# Altium schematic sheet 419-005-01-02_PG 12 - USB Connectorss.SchDoc("419-005-01-02_PG 12 - USB Connectorss")
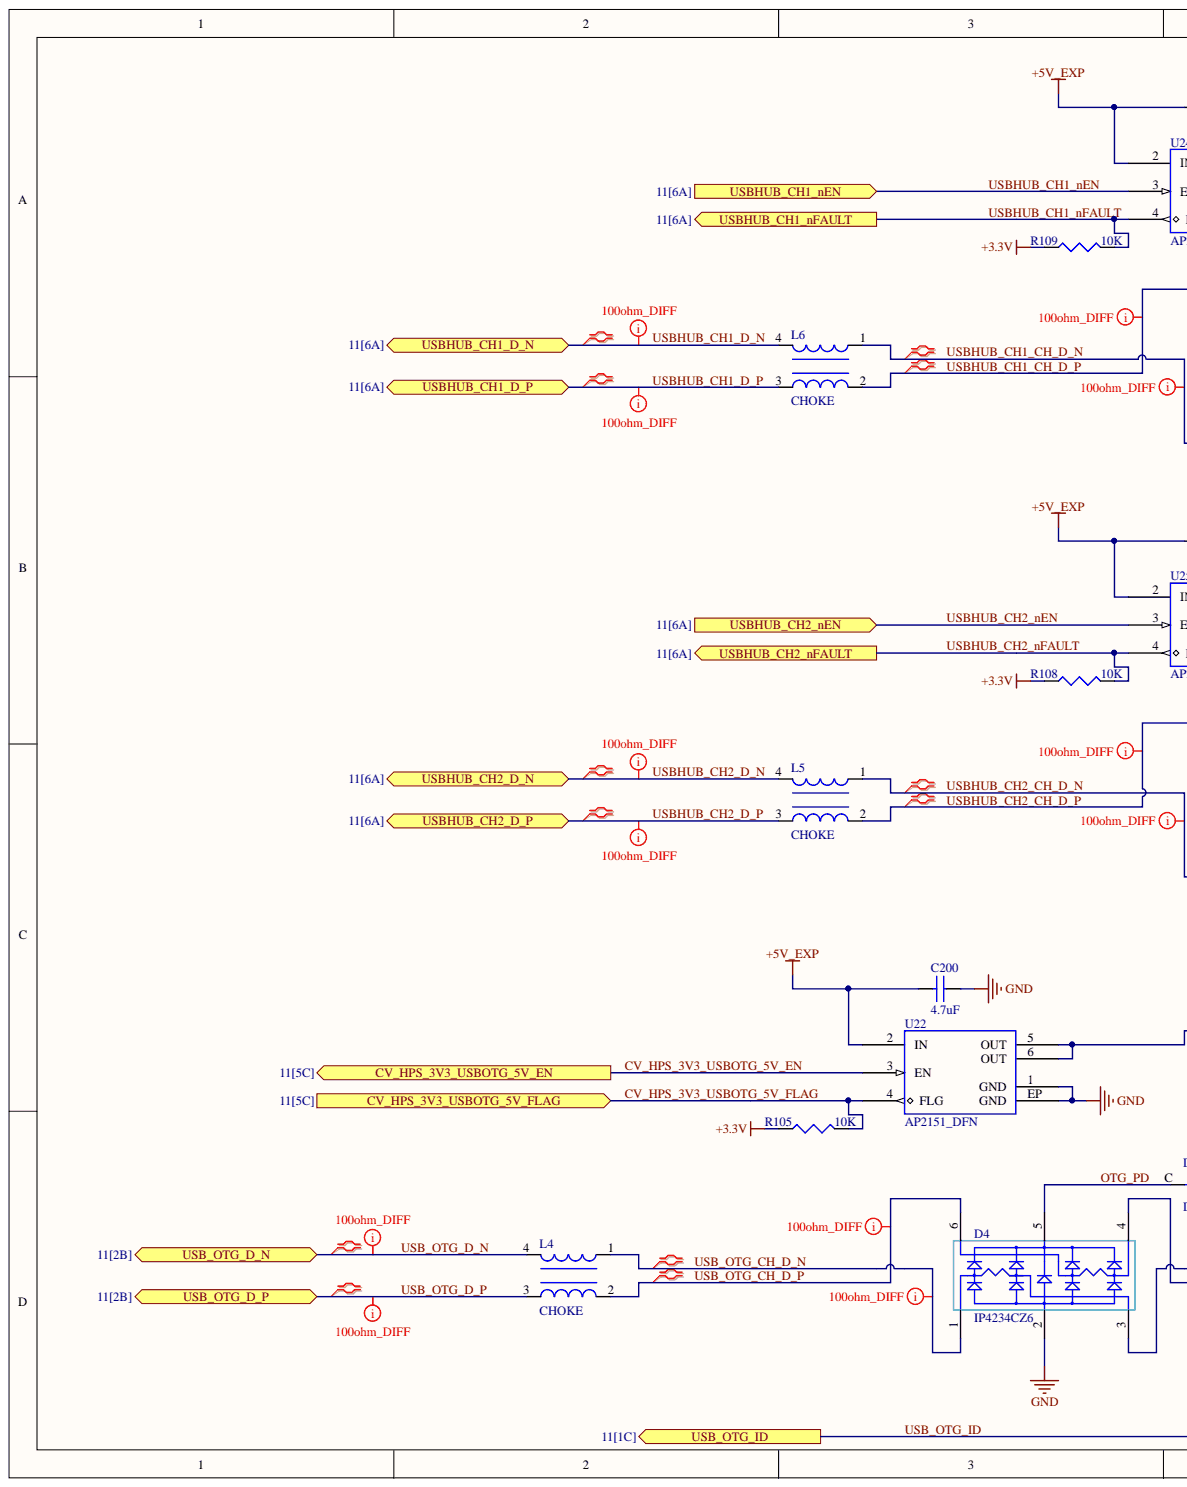
[diagram p0: 419-005-01-02_PG 12 - USB Connectorss.SchDoc, left]
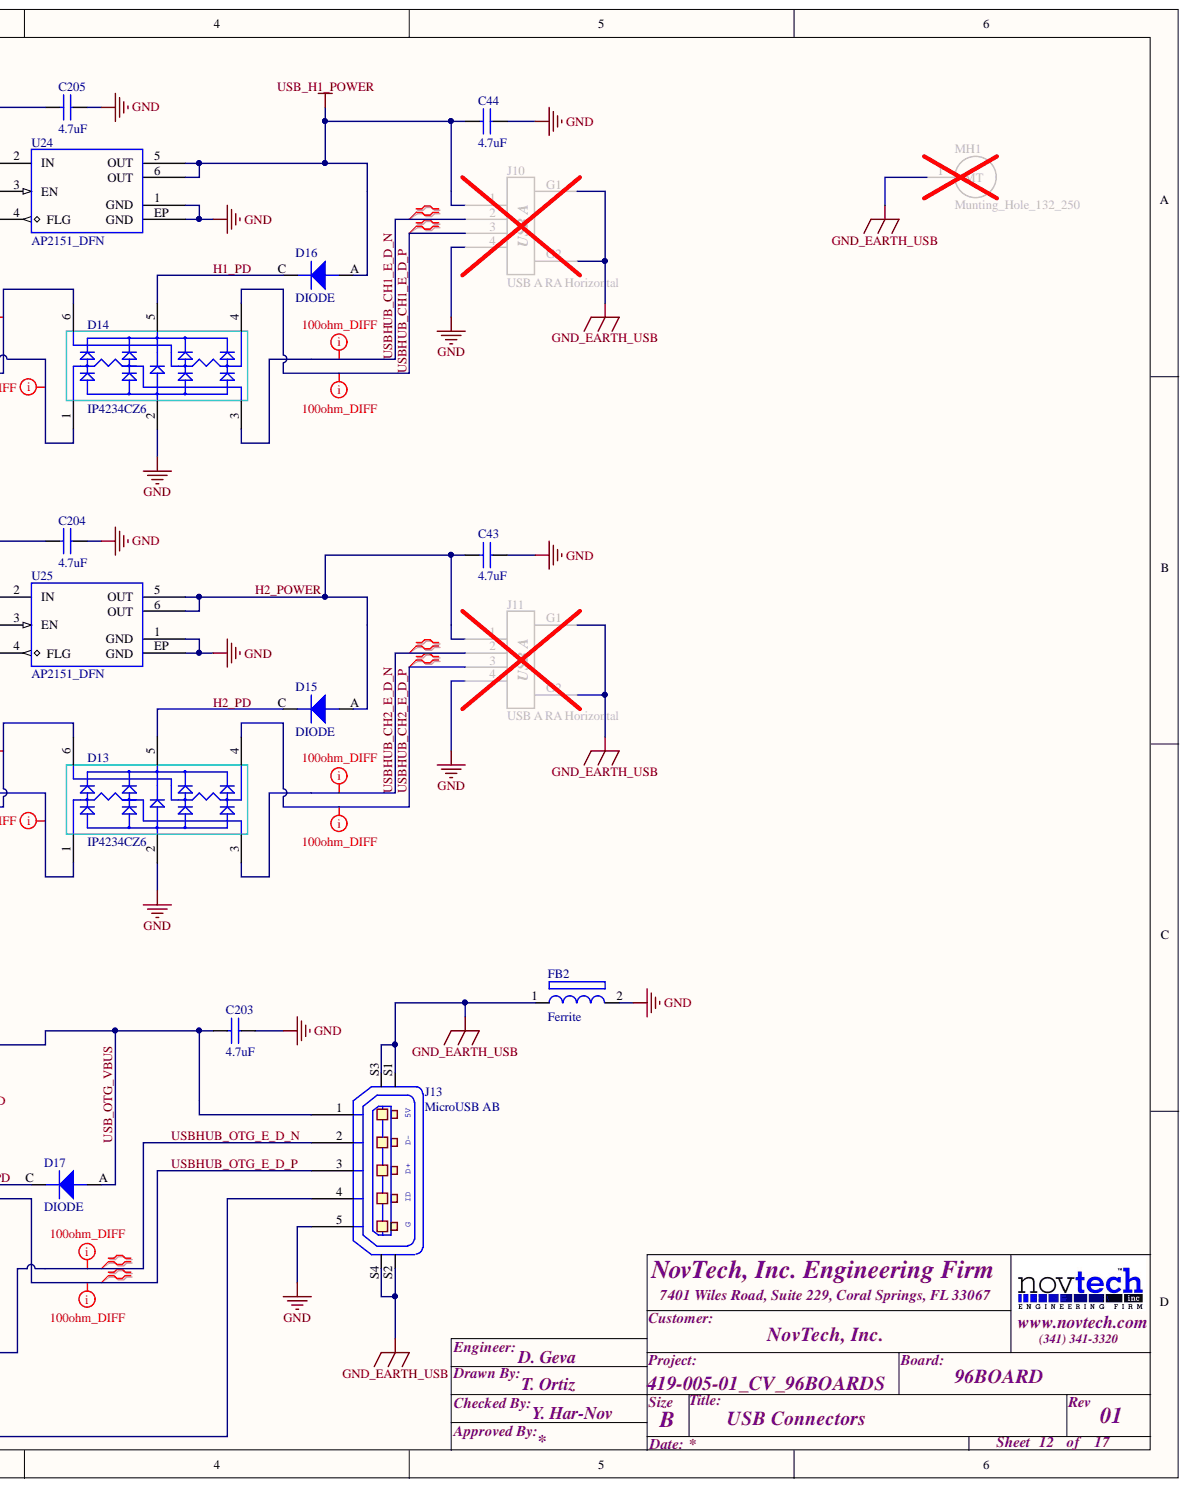
[diagram p1: 419-005-01-02_PG 12 - USB Connectorss.SchDoc, right]

## Parts
C43  4.7uF  [0402_C]
C44  4.7uF  [0402_C]
C200  4.7uF  [0402_C]
C203  4.7uF  [0402_C]
C204  4.7uF  [0402_C]
C205  4.7uF  [0402_C]
D4  IP4234CZ6  [SC-74 (SOT457)]
D13  IP4234CZ6  [SC-74 (SOT457)]
D14  IP4234CZ6  [SC-74 (SOT457)]
D15  DIODE  [0402_D]
D16  DIODE  [0402_D]
D17  DIODE  [0402_D]
FB2  Ferrite  [0402_R]
J10  USB A RA Horizontal  [USB_A_RA_H]
J11  USB A RA Horizontal  [USB_A_RA_H]
J13  MicroUSB AB  [MICROUSB_AB_475890001]
L4  CHOKE  [CHOKE_ACM2012_0805]
L5  CHOKE  [CHOKE_ACM2012_0805]
L6  CHOKE  [CHOKE_ACM2012_0805]
MH1  Munting_Hole_132_250  [MOUNTING_HOLE_132_250]
R105  10K  [0201_R]
R108  10K  [0201_R]
R109  10K  [0201_R]
U22  AP2151_DFN  [DFN6-2MMX2MM]
U24  AP2151_DFN  [DFN6-2MMX2MM]
U25  AP2151_DFN  [DFN6-2MMX2MM]

## Ports
CV_HPS_3V3_USBOTG_5V_EN, CV_HPS_3V3_USBOTG_5V_FLAG, USB_OTG_D_N, USB_OTG_D_P, USB_OTG_ID, USBHUB_CH1_D_N, USBHUB_CH1_D_P, USBHUB_CH1_NEN, USBHUB_CH1_NFAULT, USBHUB_CH2_D_N, USBHUB_CH2_D_P, USBHUB_CH2_NEN, USBHUB_CH2_NFAULT

## Nets
+3.3V: R105.1 R108.1 R109.1
+5V_EXP: C200.2 C204.2 C205.2 U22.2(IN) U24.2(IN) U25.2(IN)
GND: C43.1 C44.1 C200.1 C203.1 C204.1 C205.1 D4.2 D13.2 D14.2 FB2.2 J10.4 J11.4 J13.5 U22.1(GND) U22.EP(GND) U24.1(GND) U24.EP(GND) U25.1(GND) U25.EP(GND)
GND_EARTH_USB: FB2.1 J10.G1 J10.G2 J11.G1 J11.G2 J13.S1 J13.S2 J13.S3 J13.S4 MH1.1
USB_H1_POWER: C44.2 D16.A J10.1 U24.5(OUT) U24.6(OUT)
CV_HPS_3V3_USBOTG_5V_EN: U22.3(EN) ; port CV_HPS_3V3_USBOTG_5V_EN
CV_HPS_3V3_USBOTG_5V_FLAG: R105.2 U22.4(FLG) ; port CV_HPS_3V3_USBOTG_5V_FLAG
H1_PD: D14.5 D16.C
H2_PD: D13.5 D15.C
H2_POWER: C43.2 D15.A J11.1 U25.5(OUT) U25.6(OUT)
OTG_PD: D4.5 D17.C
USB_OTG_CH_D_N: D4.1 L4.1
USB_OTG_CH_D_P: D4.6 L4.2
USB_OTG_D_N: L4.4 ; port USB_OTG_D_N
USB_OTG_D_P: L4.3 ; port USB_OTG_D_P
USB_OTG_ID: J13.4 ; port USB_OTG_ID
USB_OTG_VBUS: C203.2 D17.A J13.1 U22.5(OUT) U22.6(OUT)
USBHUB_CH1_CH_D_N: D14.1 L6.1
USBHUB_CH1_CH_D_P: D14.6 L6.2
USBHUB_CH1_D_N: L6.4 ; port USBHUB_CH1_D_N
USBHUB_CH1_D_P: L6.3 ; port USBHUB_CH1_D_P
USBHUB_CH1_E_D_N: D14.3 J10.2
USBHUB_CH1_E_D_P: D14.4 J10.3
USBHUB_CH1_NEN: U24.3(EN) ; port USBHUB_CH1_NEN
USBHUB_CH1_NFAULT: R109.2 U24.4(FLG) ; port USBHUB_CH1_NFAULT
USBHUB_CH2_CH_D_N: D13.1 L5.1
USBHUB_CH2_CH_D_P: D13.6 L5.2
USBHUB_CH2_D_N: L5.4 ; port USBHUB_CH2_D_N
USBHUB_CH2_D_P: L5.3 ; port USBHUB_CH2_D_P
USBHUB_CH2_E_D_N: D13.3 J11.2
USBHUB_CH2_E_D_P: D13.4 J11.3
USBHUB_CH2_NEN: U25.3(EN) ; port USBHUB_CH2_NEN
USBHUB_CH2_NFAULT: R108.2 U25.4(FLG) ; port USBHUB_CH2_NFAULT
USBHUB_OTG_E_D_N: D4.3 J13.2
USBHUB_OTG_E_D_P: D4.4 J13.3

## Library data of the parts
C43 C44 C200 C203-C205 = CAP_0402: Capasitor 0402 footprint; Bottom: 10; Right: 20; Component Kind: Standard; Library Name: PASSIVES.SchLib
D4 D13 D14 = IP4234CZ6: PART: IP4234CZ6,125; MFG: NXP; TEMP: -40°C to +85°C; TYPE: SMT; Component Kind: Standard; DigiKey: 568-5869-1-ND; Library Name: PASSIVES.SchLib; RoHS: Yes
D15-D17 = DIODE: PART: CDSQR4148; Marking: S3; MFG: Comchip; TEMP: +125°C; TYPE: SMT; Component Kind: Standard; DigiKey: 641-1287-2-ND; Library Name: PASSIVES.SchLib; RoHS: Yes
FB2 = INDUCTOR_BEAD: TEMP: -55°C to +85°C; TYPE: SMT; Component Kind: Standard; Library Name: PASSIVES.SchLib; RoHS: Yes
J10 J11 = USB A Horizontal: PART: 87520-0010BLF; MFG: FCI; Component Kind: Standard; DigiKey: 609-1045-ND; Library Name: CONNECTORS_MECHANICAL.SchLib; RoHS: Yes
J13 = USB: PART: 0475890001; MFG: Molex; Component Kind: Standard; DigiKey: WM17143CT-ND; Library Name: CONNECTORS_MECHANICAL.SchLib; RoHS: Yes; TYPE: SMT
L4-L6 = CHOCKE: PART: ACM2012-900-2P-T001; MFG: TDK; TEMP: -40°C to +85°C; TYOE: SMT; Component Kind: Standard; Digikey: 445-15969-1-ND; Library Name: PASSIVES.SchLib; RoHS: Yes
MH1 = Munting_Hole: PART: Not a Part; Component Kind: Standard; Library Name: CONNECTORS_MECHANICAL.SchLib
R105 R108 R109 = RESISOTR 0201: Resistor 0201 footprint; PART: ERJ-1GEF1002C; MFG: Panasonic; Component Kind: Standard; Digikey: P10.0KABCT-ND; Library Name: PASSIVES.SchLib; RoHS: Yes; TYPE: SMT
U22 U24 U25 = AP2151_DFN: PART: AP2151FMG-7; Marking: HS; MFG: Diode Inc.; TEMP: -40°C to +85°C; Component Kind: Standard; DigiKey: AP2151FMG-7DITR-ND; Library Name: IC_SchLib.SCHLIB; RoHS: Yes; TYPE: SMT
